# Altium schematic sheet [03] - SCHEMATIC.SchDoc("[03] - SCHEMATIC")
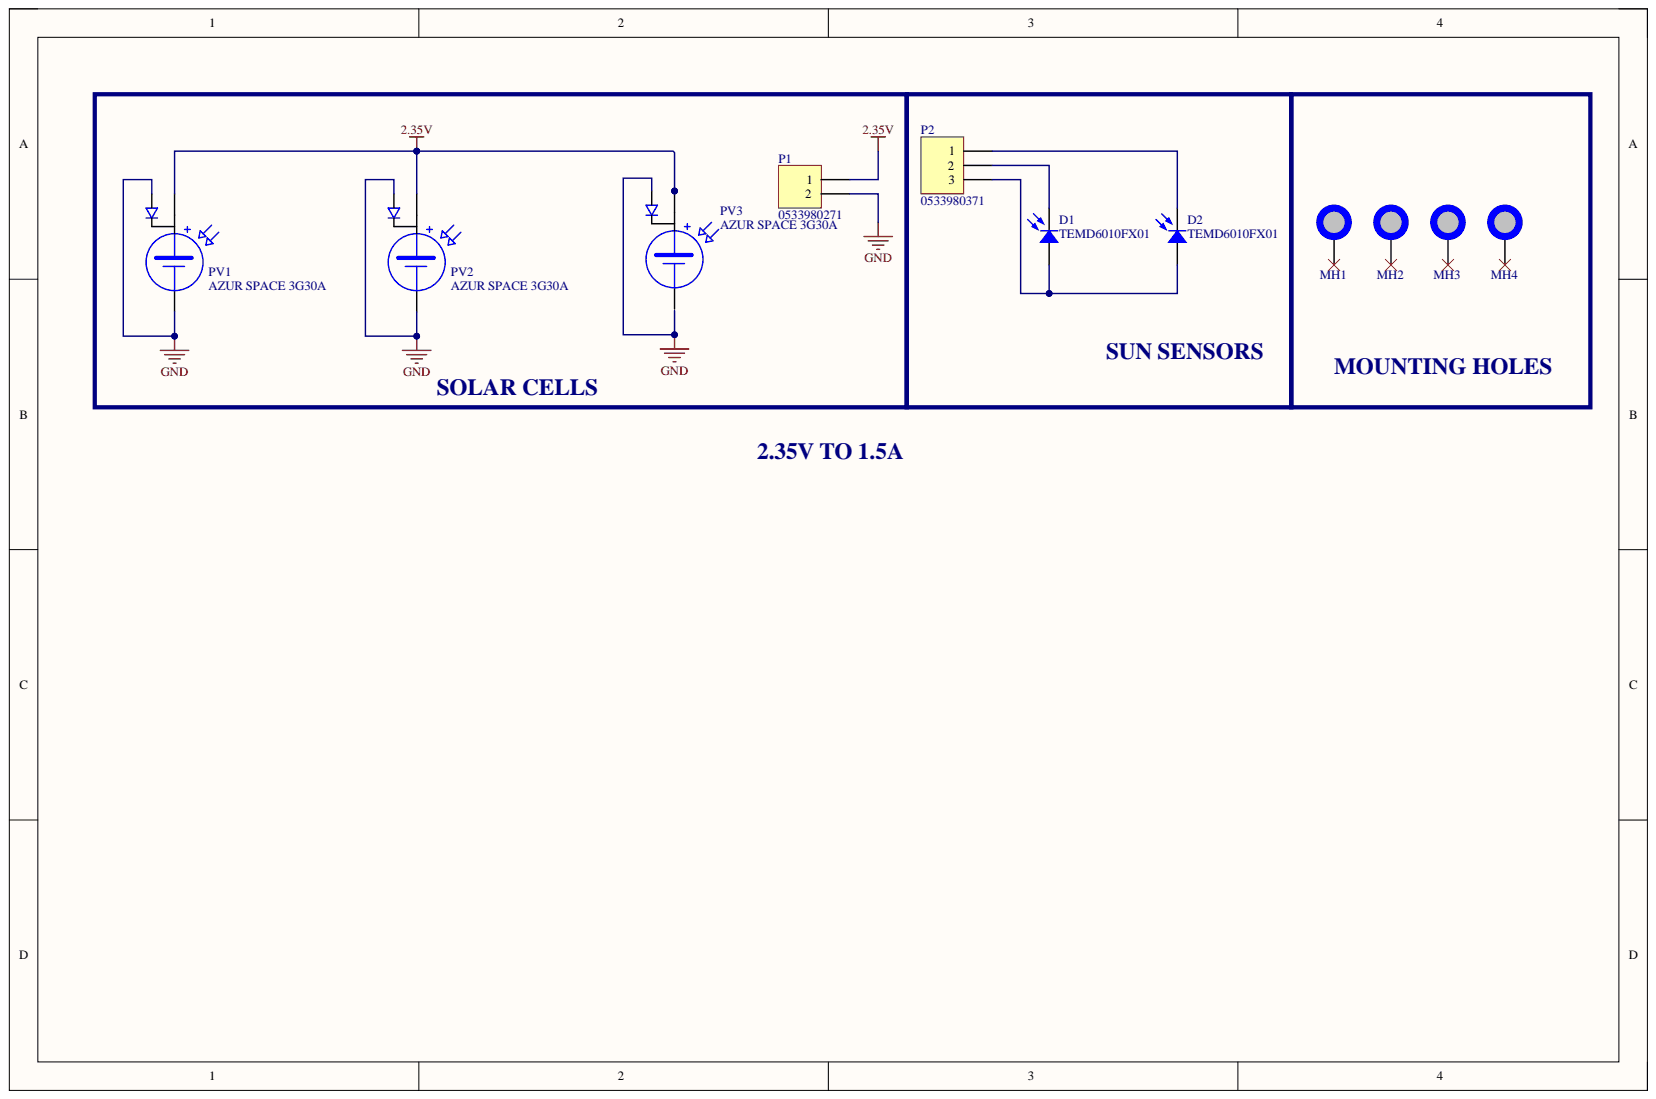
[diagram p0: [03] - SCHEMATIC.SchDoc]

## Parts
D1  TEMD6010FX01  [TEMD6010FX01]
D2  TEMD6010FX01  [TEMD6010FX01]
MH1  MH_3.8MMNC_2.5MMD  [MH_3.8MMNC_2MMD_NON_COPPER_NPTH]  (value not drawn)  (no BOM)
MH2  MH_3.8MMNC_2.5MMD  [MH_3.8MMNC_2MMD_NON_COPPER_NPTH]  (value not drawn)  (no BOM)
MH3  MH_3.8MMNC_2.5MMD  [MH_3.8MMNC_2MMD_NON_COPPER_NPTH]  (value not drawn)  (no BOM)
MH4  MH_3.8MMNC_2.5MMD  [MH_3.8MMNC_2MMD_NON_COPPER_NPTH]  (value not drawn)  (no BOM)
P1  0533980271  [0533980271]
P2  0533980371  [0533980371]
PV1  AZUR SPACE 3G30A  [AZUR SPACE 3G30A]
PV2  AZUR SPACE 3G30A  [AZUR SPACE 3G30A]
PV3  AZUR SPACE 3G30A  [AZUR SPACE 3G30A]

## Nets
2.35V: P1.1 PV1.1 PV2.1 PV3.1
GND: P1.2 PV1.2 PV1.3 PV2.2 PV2.3 PV3.3
NetD1_1: D1.1 D2.1 P2.3
NetD1_2: D1.2 P2.2
NetD2_2: D2.2 P2.1

Not connected: MH1 1; MH2 1; MH3 1; MH4 1; PV3 2

## Library data of the parts
D1 D2 = TEMD6010FX01: Ambient Light Sensor; Manufacturer: Vishay Semiconductors; Active Area: 0.27mm²; Catalog Drawings: TEMx60x0(F)X01; Current - Dark (Typ): 2nA; Diode Type: PIN; Featured Product: Surface-Mount Ambient Light Sensing Photodiodes; Other Names: 751-1051-2; Product Training Modules: Ambient Light Sensors; Spectral Range: 430nm ~ 610nm; Viewing Angle: 120°; Voltage - DC Reverse (Vr) (Max): 16V; Wavelength: 540nm; Category: Sensors, Transducers; Component Kind: Standard; ComponentLink1Description: https://datasheet.ciiva.com/8280/temd6010-8280593.pdf; ComponentLink1URL: https://datasheet.ciiva.com/8280/temd6010-8280593.pdf; ComponentLink2Description: https://datasheet.ciiva.com/1252/temd6010-1252130.pdf; ComponentLink2URL: https://datasheet.ciiva.com/1252/temd6010-1252130.pdf; ComponentLink3Description: https://datasheet.ciiva.com/2819/temd6010-2819730.pdf; ComponentLink3URL: https://datasheet.ciiva.com/2819/temd6010-2819730.pdf; ComponentLink4Description: https://datasheet.ciiva.com/2819/temd6010-2819731.pdf; ComponentLink4URL: https://datasheet.ciiva.com/2819/temd6010-2819731.pdf; ComponentLink5Description: https://datasheet.ciiva.com/2819/temd6010-2819732.pdf; ComponentLink5URL: https://datasheet.ciiva.com/2819/temd6010-2819732.pdf; ComponentLink6Description: https://datasheet.ciiva.com/4270/temd6010-4270070.pdf; ComponentLink6URL: https://datasheet.ciiva.com/4270/temd6010-4270070.pdf; ComponentLink7Description: https://datasheet.ciiva.com/46430/1794172-46430214.pdf; ComponentLink7URL: https://datasheet.ciiva.com/46430/1794172-46430214.pdf; ComponentLink8Description: https://datasheet.ciiva.com/1249/temd6010-1249179.pdf; ComponentLink8URL: https://datasheet.ciiva.com/1249/temd6010-1249179.pdf; ComponentLink9Description: https://datasheet.ciiva.com/4697/temd6010-102586-4697659.pdf; ComponentLink9URL: https://datasheet.ciiva.com/4697/temd6010-102586-4697659.pdf; Family: Optical Sensors - Photodiodes; Manufacturer Part Number: TEMD6010FX01; Mounting Type: Surface Mount; Online Catalog: Ambient Light Sensor; Operating Temperature: -40°C ~ 100°C; Packaging: Tape & Reel (TR); Pricing 1: 1=2.26, 10=1.998, 25=1.4824, 100=1.2892, 250=1.1603, 500=1.0314, 1000=0.8863 (USD); Standard Package: 3,000; Stock 1: 29; Supplier 1: Digi-Key; Supplier Part Number 1: 751-1051-1-ND; Library Name: UVG.IntLib
MH1-MH4 = MH_3.8MMNC_2MMD: Mounting Hole 3.8mm Diameter (Non Copper), 2.5mm Drill NPTH; Library Name: UVG.SchLib
P1 = 0533980271: 2 Positions Header, Shrouded Connector 0.049" (1.25mm) Surface Mount, Right Angle Tin; Manufacturer: Molex; Number of Positions: 2; Number of Positions Loaded: All; Category: Connectors, Interconnects; Color: Natural; Component Kind: Standard; ComponentLink1Description: https://datasheet.ciiva.com/6813/533980271-sd-6813860.pdf; ComponentLink1URL: https://datasheet.ciiva.com/6813/533980271-sd-6813860.pdf; ComponentLink2Description: https://datasheet.ciiva.com/3117/0533980271-pcb-headers-3117624.pdf; ComponentLink2URL: https://datasheet.ciiva.com/3117/0533980271-pcb-headers-3117624.pdf; ComponentLink3Description: https://datasheet.ciiva.com/3117/0533980271-pcb-headers-3117625.pdf; ComponentLink3URL: https://datasheet.ciiva.com/3117/0533980271-pcb-headers-3117625.pdf; ComponentLink4Description: https://datasheet.ciiva.com/6813/533980271-sd-6813869.pdf; ComponentLink4URL: https://datasheet.ciiva.com/6813/533980271-sd-6813869.pdf; Connector Type: Header, Shrouded; Contact Finish: Tin; Contact Finish Thickness: 39µin (1.00µm); Contact Mating Length: -; Contact Type: Blade Pin; Family: Rectangular Connectors - Headers, Male Pins; Fastening Type: -; Features: Solder Retention; Manufacturer Part Number: 0533980271; Mounting Type: Surface Mount; Number of Rows: 1; Packaging: Cut Tape (CT); Pitch: 0.049" (1.25mm); Pricing 1: 1=0.88, 10=0.778, 100=0.6713, 500=0.5493 (USD); Row Spacing: -; Series: PicoBlade™ 53398; Stock 1: 25214; Supplier 1: Digi-Key; Supplier Part Number 1: WM7606CT-ND; Termination: Solder; Library Name: UVG.IntLib
P2 = 0533980371: 3 Positions Header, Shrouded Connector 0.049" (1.25mm) Surface Mount Tin; Manufacturer: Molex; Number of Positions: 3; Number of Positions Loaded: All; Category: Connectors, Interconnects; Color: Natural; Component Kind: Standard; ComponentLink1Description: https://datasheet.ciiva.com/6813/533980271-sd-6813860.pdf; ComponentLink1URL: https://datasheet.ciiva.com/6813/533980271-sd-6813860.pdf; ComponentLink2Description: https://datasheet.ciiva.com/3117/0533980371-pcb-headers-3117626.pdf; ComponentLink2URL: https://datasheet.ciiva.com/3117/0533980371-pcb-headers-3117626.pdf; ComponentLink3Description: https://datasheet.ciiva.com/3117/0533980371-pcb-headers-3117627.pdf; ComponentLink3URL: https://datasheet.ciiva.com/3117/0533980371-pcb-headers-3117627.pdf; Connector Type: Header, Shrouded; Contact Finish: Tin; Contact Finish Thickness: 39µin (1.00µm); Contact Mating Length: -; Contact Type: Blade Pin; Family: Rectangular Connectors - Headers, Male Pins; Fastening Type: -; Features: Solder Retention; Manufacturer Part Number: 0533980371; Mounting Type: Surface Mount; Number of Rows: 1; Packaging: Digi-Reel®; Pitch: 0.049" (1.25mm); Pricing 1: 1=1.07, 10=0.942, 100=0.8126, 500=0.6649 (USD); Row Spacing: -; Series: PicoBlade™ 53398; Stock 1: 26974; Supplier 1: Digi-Key; Supplier Part Number 1: WM7607CT-ND; Termination: Solder; Library Name: UVG.IntLib
PV1-PV3 = AZUR SPACE 3G30A: 30% Triple Junction GaAs Solar Cell Assembly; Average Efficiency (1353 W/m^2): 29.6; Average Efficiency (1367 W/m^2): 29.3; Average Open Circuit (BOL): 2690 mV; Average Short Circuit (BOL): 519.6 mA; Current at max. Power: 502.9 mA; Voltage at max.Power: 2409 mV; Component Kind: Standard
  PV1 PV2: Library Name: UVG.IntLib
  PV3: Library Name: PT-MIS-PCB-007_v1.SCHLIB
